# Altium schematic sheet connectors.SchDoc
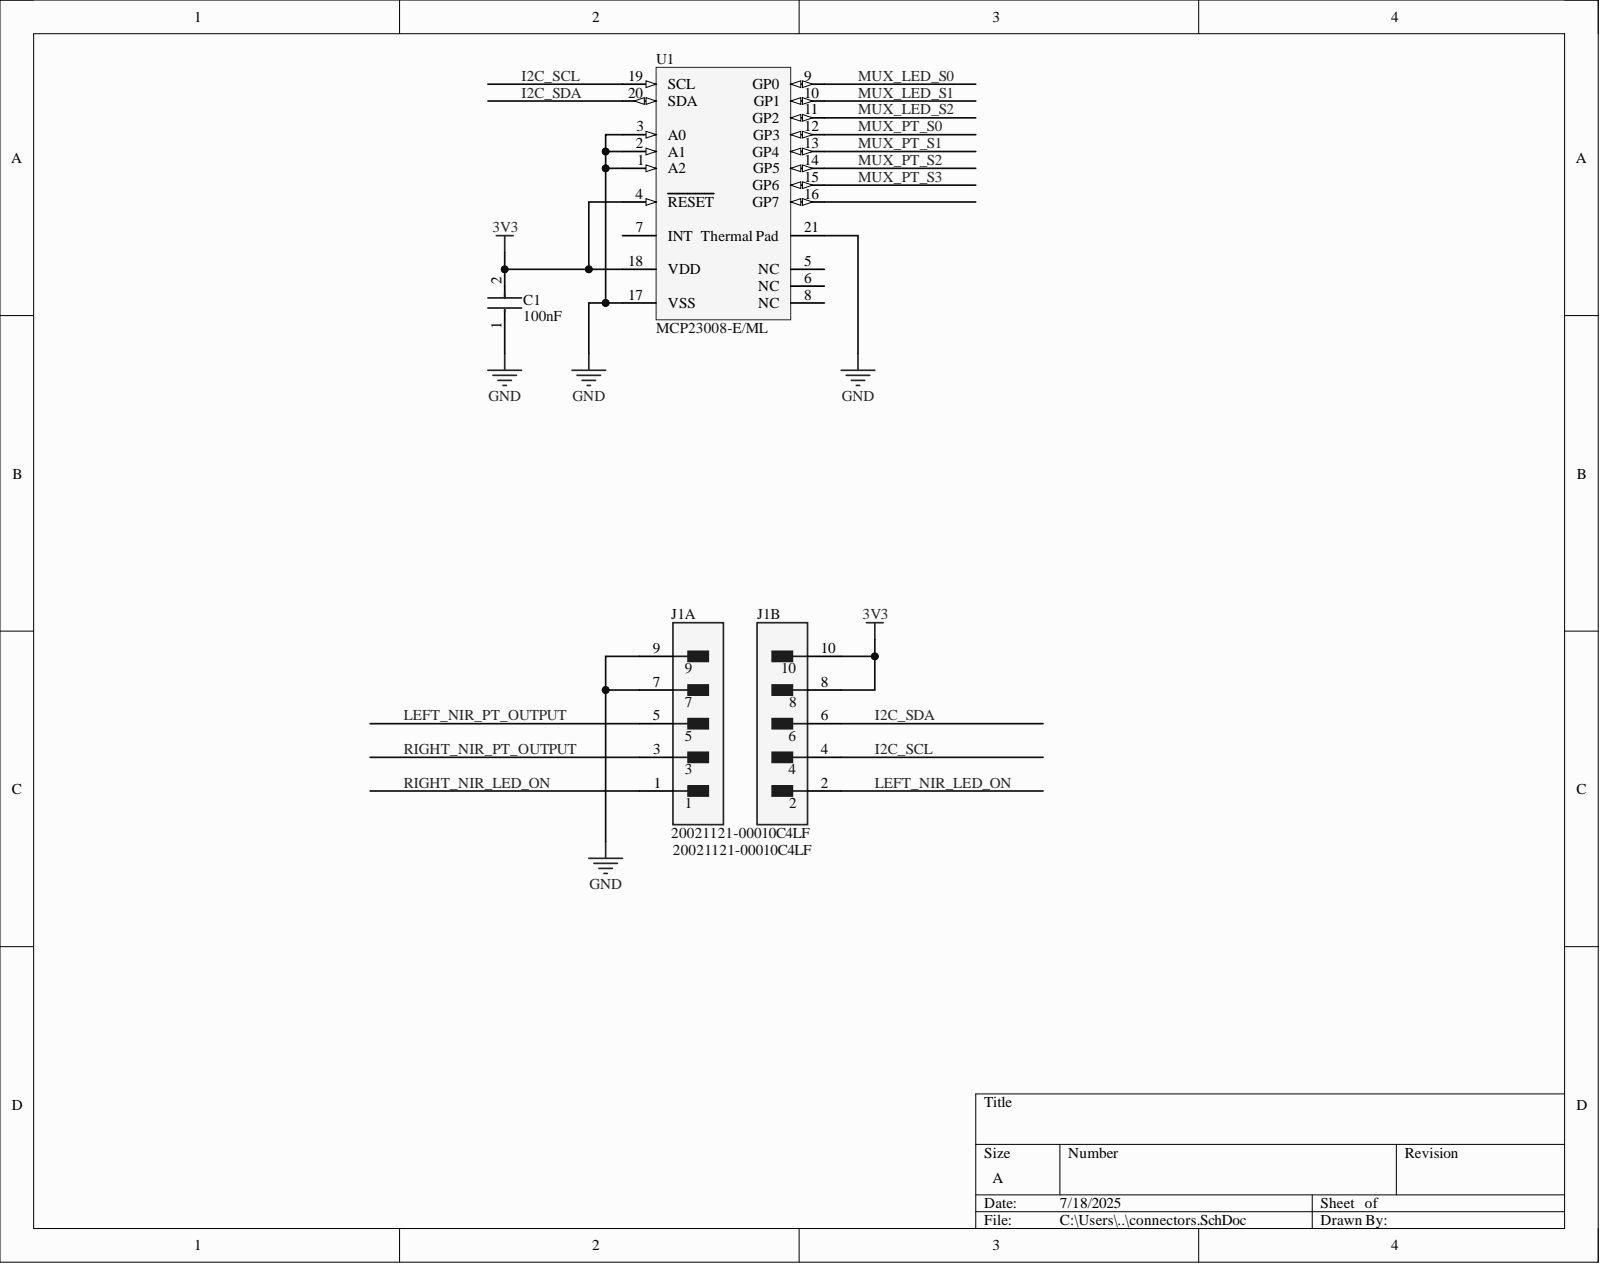
[diagram p0: connectors.SchDoc]

## Parts
C1  Capacitance: 100nF  [FP-GRM15-MFG]  (hidden comment: GRM155R71C104KA88D)
J1  20021121-00010C4LF  [FP-20021121-00010C4LF-MFG]  (parts A,B)
U1  MCP23008-E/ML  [QFN-ML20_M]

## Nets
3V3: C1.2 J1.8 J1.10 U1.4(RESET) U1.18(VDD)
GND: C1.1 J1.7 J1.9 U1.1(A2) U1.2(A1) U1.3(A0) U1.17(VSS) U1.21(Thermal_Pad)
I2C_SCL: J1.4 U1.19(SCL)
I2C_SDA: J1.6 U1.20(SDA)
LEFT_NIR_LED_ON: J1.2
LEFT_NIR_PT_OUTPUT: J1.5
MUX_LED_S0: U1.9(GP0)
MUX_LED_S1: U1.10(GP1)
MUX_LED_S2: U1.11(GP2)
MUX_PT_S0: U1.12(GP3)
MUX_PT_S1: U1.13(GP4)
MUX_PT_S2: U1.14(GP5)
MUX_PT_S3: U1.15(GP6)
RIGHT_NIR_LED_ON: J1.1
RIGHT_NIR_PT_OUTPUT: J1.3

Not connected: U1 5(NC) 6(NC) 7(INT) 8(NC) 16(GP7)

## Library data of the parts
C1 = CMP-2100-03641-2: CAP CER 0.1UF 16V X7R 0402; Manufacturer: Murata; Material: Ceramic; Standoff Height: 0mm; Tolerance: 10%; Value: 0.10μF; Voltage Rating: 16Vdc; Category: Cap; Component Kind: Standard; ComponentLink2Description: Datasheet; ComponentLink2URL: https://search.murata.co.jp/Ceramy/image/img/A01X/G101/ENG/GRM155R71H471KA01-01A.pdf; Device Class L1: Passive Components; Device Class L2: Capacitors; Device Class L3: Ceramic Capacitors; Digikey Description: CAP CER 0.1UF 16V X7R 0402; Height: 0.55mm; Ipc Land Pattern Name: CAPC10050X219; Lead Free: yes; Library Name: Altium Content Vault; Manufacturer Part Number: GRM155R71C104KA88D; Mouser Description: 0402 0.1 uF 16V ±10 % Tolerance X7R SMT Multilayer Ceramic Capacitor; Octopart: https://octopart.com/grm155r71c104ka88d-murata-196880; Package: GRM15; RoHS: TRUE; Temperature: -55°C to +125°C; Temperature Characteristic: X7R; Temperature Range High: +125°C; Temperature Range Low: -55°C
J1 = CMP-15126-126517171-1: 20021121-00010C4LF Conn Unshrouded Header HDR 10 POS 1.27mm Solder ST Top Entry SMD Minitek127® T/R; Connector Type: Header, Male Pin; Contact Resistance: 30mΩ; Current Rating: 1A; Gender: Male; Insulation Resistance: 100MΩ; Mating Post Length: 3.05mm; Max Voltage Rating (AC): 125V; Number of Contacts: 10; Stack Height: 3mm; Color: Black; Component Kind: Standard; Contact Material: Copper, Gold; Contact Mating Length: 3.048mm; Contact Plating: Gold; Depth: 3.4mm; Height: 4.8mm; Housing Color: Black; Housing Material: Thermoplastic; Length: 6.35mm; Library Name: Altium Content Vault; Max Operating Temperature: 85°C; Min Operating Temperature: -55°C; Mount: Surface Mount; NexarPartId: 126517171; Number of Pins: 10; Number of Positions: 10; Number of Rows: 2; Orientation: Straight; Pitch: 1.27mm; Radiation Hardening: No; REACH SVHC: No SVHC; RoHS: Compliant; Row Spacing: 1.27mm; Termination: Solder; Voltage Rating: 125V
U1 = CMP-0186-00018-2: 8-Bit I/O Expander with Serial Interface, 20-Pin QFN, Extended Temperature; Component Kind: Standard; ComponentLink1Description: Manufacturer URL; ComponentLink1URL: http://www.microchip.com/; ComponentLink2Description: Datasheet; ComponentLink2URL: http://ww1.microchip.com/downloads/en/DeviceDoc/21919e.pdf; ComponentLink3Description: Package Specification; ComponentLink3URL: http://www.microchip.com/stellent/groups/techpub_sg/documents/packagingspec/en012702.pdf; DatasheetVersion: revE, Aug-2007; Library Name: Altium Content Vault; PackageDescription: 20-Lead Plastic Quad Flat, No Lead Package (ML) - 4x4x0.9 mm Body [QFN]; PackageReference: QFN-ML20; PackageVersion: revBB, Aug-2009; RevisionNote: URLs added.
